# Altium schematic sheet nuae_main_sch.SchDoc
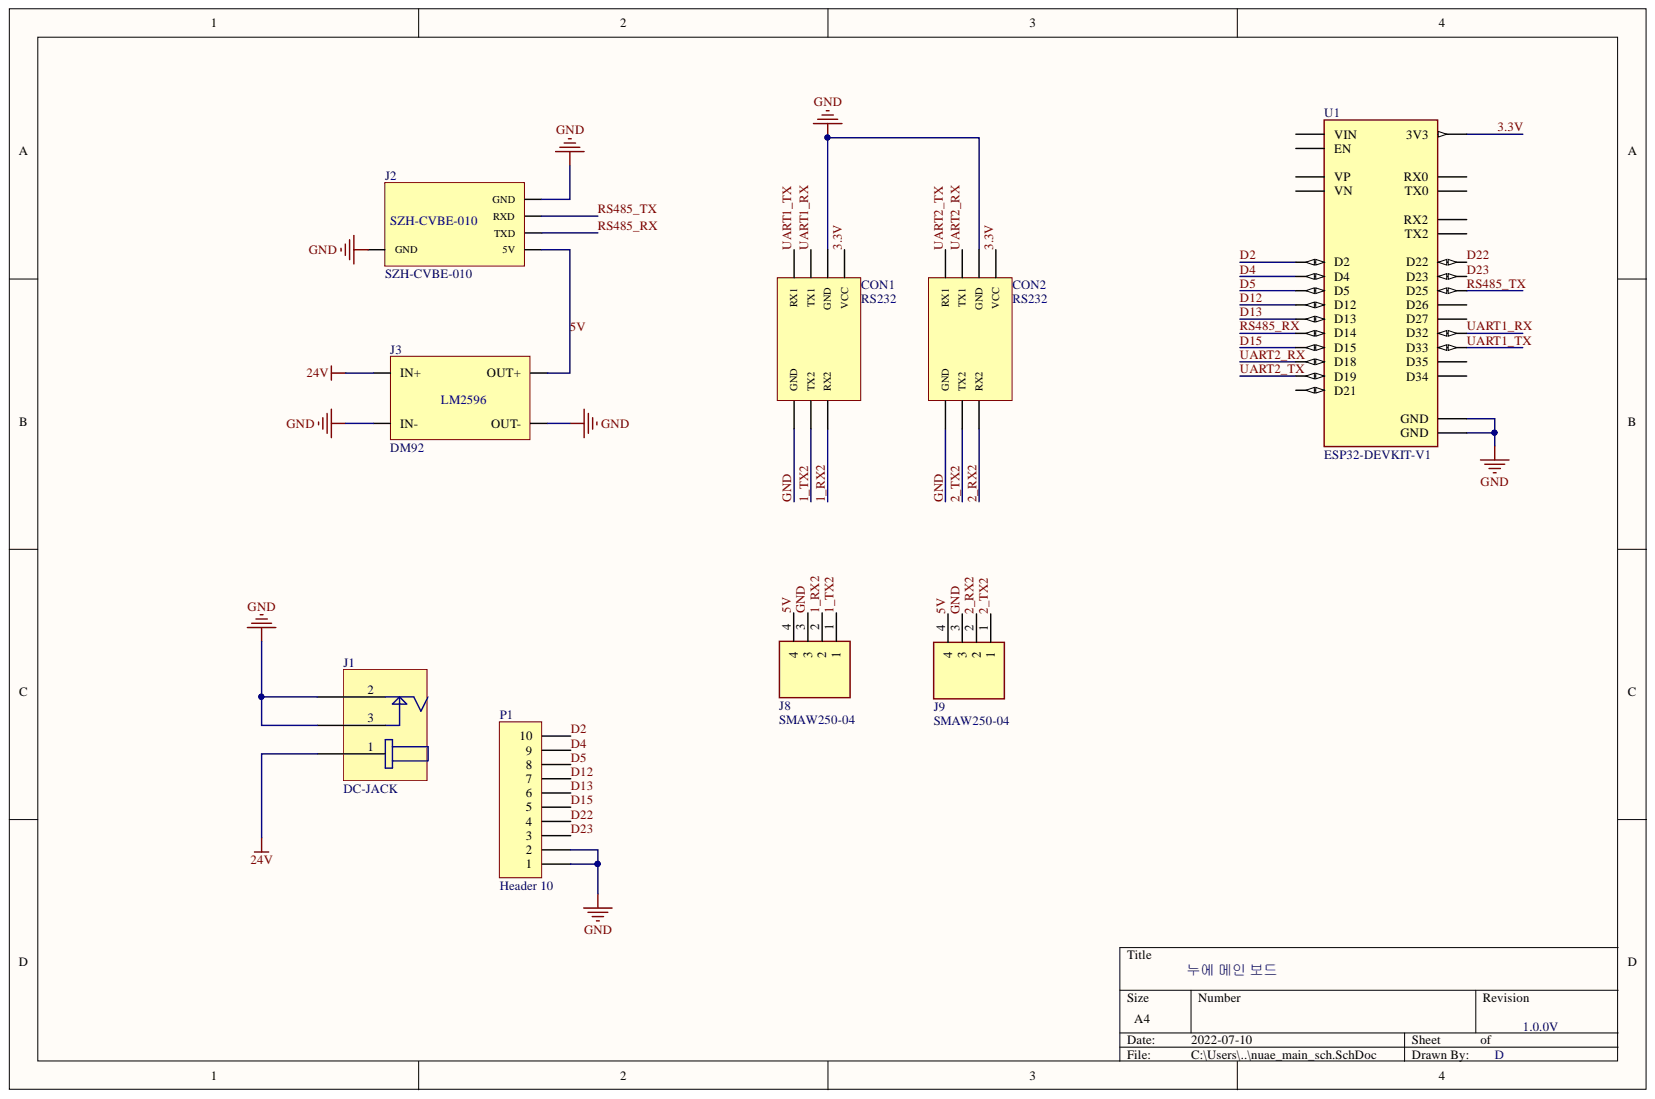
[diagram p0: nuae_main_sch.SchDoc]

## Parts
CON1  RS232  [RS232-MODULE]
CON2  RS232  [RS232-MODULE]
J1  DC-JACK  [DC-JACK]
J2  SZH-CVBE-010  [RS485-MODULE]
J3  DM92  [REGULATOR]
J8  SMAW250-04  [SHDRRA4W64P0X250_1X4_1250X900X580P]
J9  SMAW250-04  [SHDRRA4W64P0X250_1X4_1250X900X580P]
P1  Header 10  [HDR1X10]
U1  ESP32-DEVKIT-V1  [ESP32]

## Nets
24V: J1.1 J3.24V
1_RX2: CON1.RX2 J8.2
1_TX2: CON1.TX2 J8.1
2_RX2: CON2.RX2 J9.2
2_TX2: CON2.TX2 J9.1
3.3V: CON1.VCC CON2.VCC U1.3V3
5V: J2.5V J3.5V J8.4 J9.4
D2: P1.10 U1.D2
D4: P1.9 U1.D4
D5: P1.8 U1.D5
D12: P1.7 U1.D12
D13: P1.6 U1.D13
D15: P1.5 U1.D15
D22: P1.4 U1.D22
D23: P1.3 U1.D23
GND: CON1.GND CON2.GND J1.2 J1.3 J2.GND J3.GND J8.3 J9.3 P1.1 P1.2 U1.GND
RS485_RX: J2.TXD U1.D14
RS485_TX: J2.RXD U1.D25
UART1_RX: CON1.TX1 U1.D32
UART1_TX: CON1.RX1 U1.D33
UART2_RX: CON2.TX1 U1.D18
UART2_TX: CON2.RX1 U1.D19

Not connected: U1 D21 D26 D27 D34 D35 EN RX0 RX2 TX0 TX2 VIN VN VP

## Library data of the parts
CON1 CON2 = RS232: MP: EXAR 20X16MM; Component Kind: Standard; Description: RS232; Library Name: NuaeSensorSchLib.SchLib
J1 = DC-JACK: MP: DC-005-20A; Component Kind: Standard; Library Name: NuaeMainSchLib.SchLib
J3 = LM2596: LM2596 모듈; Component Kind: Standard; MP: DM92; Library Name: NuaeSensorSchLib.SchLib
J8 J9 = SMAW250-04: Connector; Component Kind: Standard
  J8: MP: SMAW250-04
  J9: Manufacturer_Part_Number: SMAW250-04
P1 = Header 10: Header, 10-Pin; Component Kind: Standard; LatestRevisionDate: 17-Jul-2002; LatestRevisionNote: Re-released for DXP Platform.; Library Name: Miscellaneous Connectors.IntLib; Signal Integrity: Connector; Publisher: Altium Limited
U1 = ESP32-DEVKIT-V1: Dual core, Wi-Fi: 2.4 GHz up to 150 Mbits/s,BLE (Bluetooth Low Energy) and legacy Bluetooth, 32 bits, Up to 240 MHz; Component Kind: Standard; Library Name: ESP32-DEVKIT-V1.SchLib; MP: ESP32-DEVKIT-V1
J2 = SZH-CVBE-010: Component Kind: Standard; MP: SZH-CVBE-010; Library Name: NuaeSensorSchLib.SchLib
